# Altium schematic sheet 300mm 26V_DC Fan_V01.SchDoc
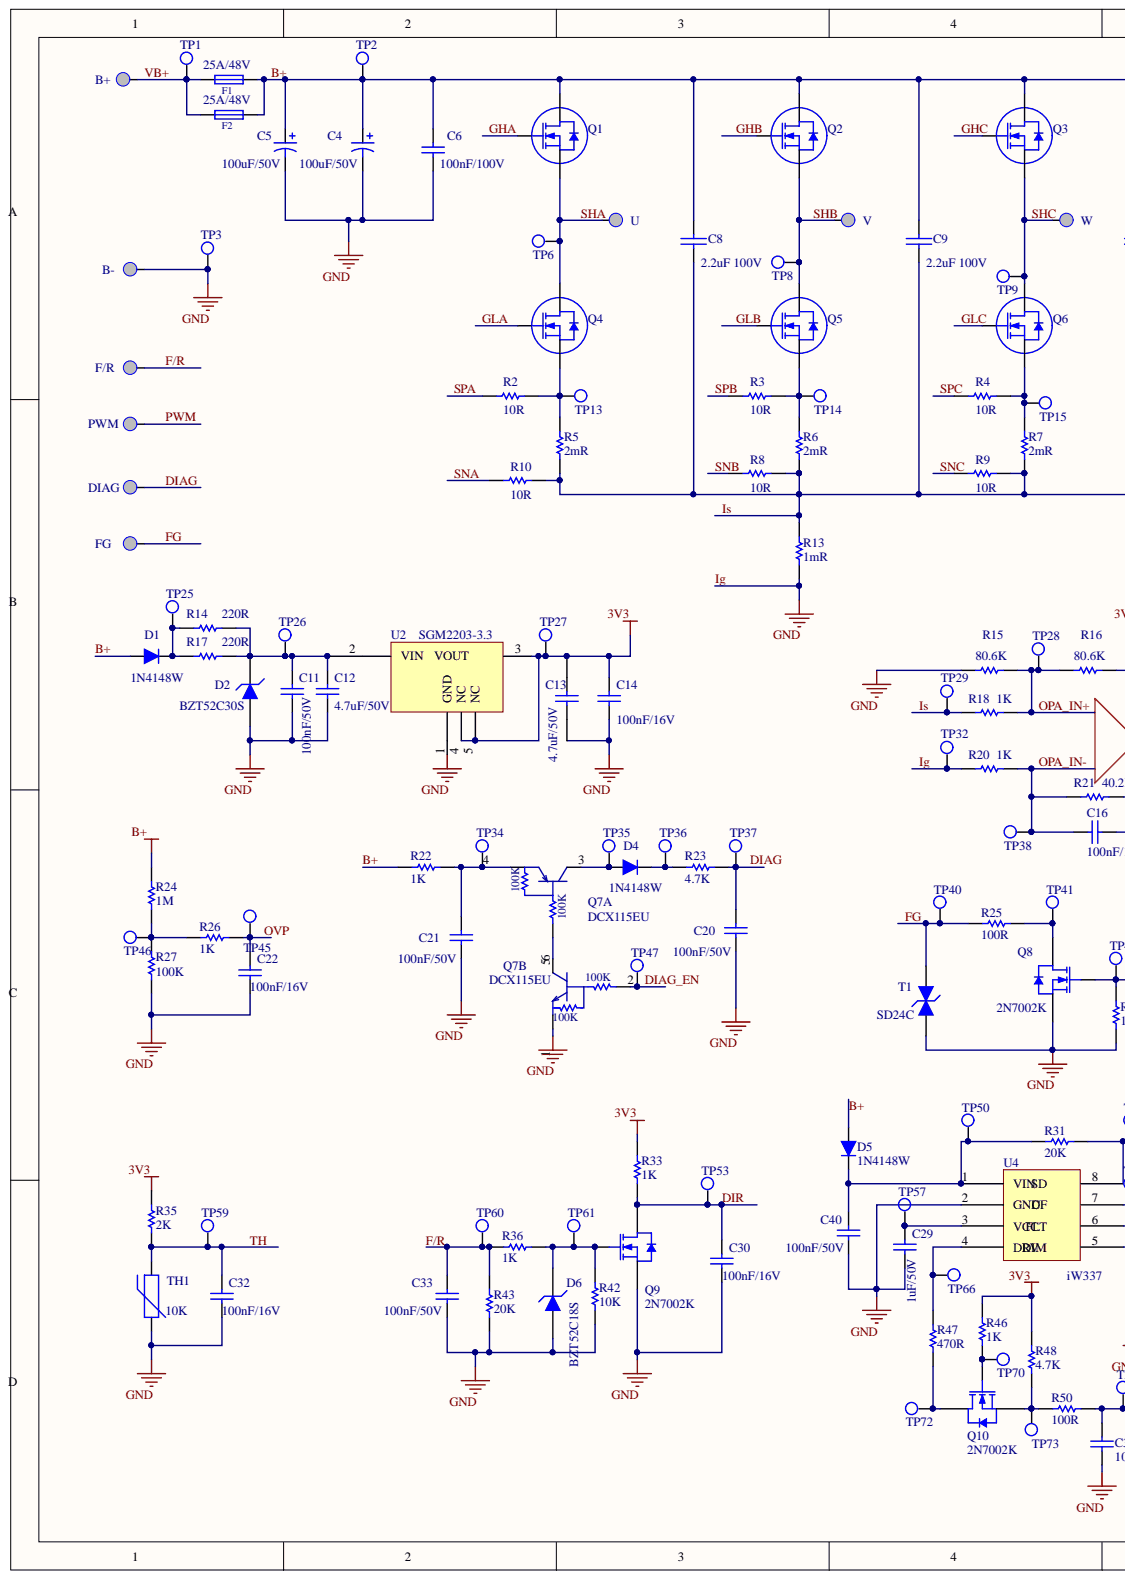
[diagram p0: 300mm 26V_DC Fan_V01.SchDoc, left]
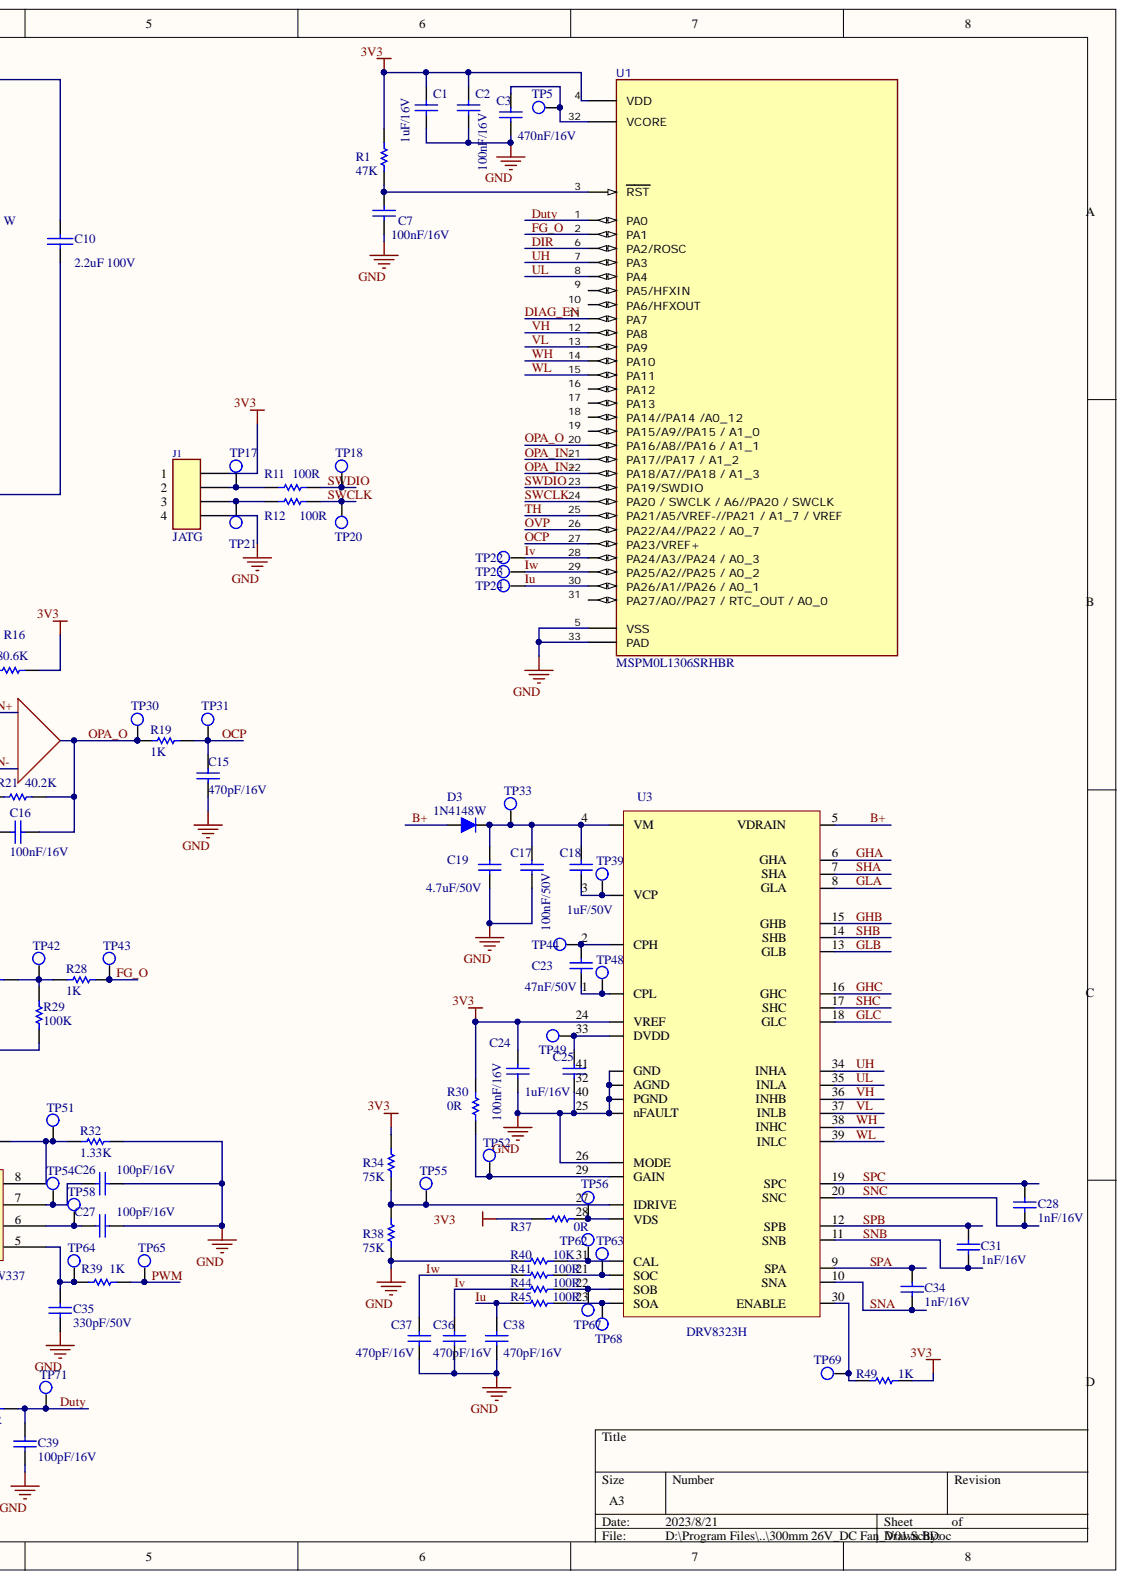
[diagram p1: 300mm 26V_DC Fan_V01.SchDoc, right]

## Parts
B+  value B+  [HOLE1.6]  (hidden comment: CONN)
B-  value B-  [HOLE1.6]  (hidden comment: CONN)
C1  value 1uF/16V  [C0603_N]  (hidden comment: Capacitor)
C2  value 100nF/16V  [C0603_N]  (hidden comment: Capacitor)
C3  value 470nF/16V  [C0603_N]  (hidden comment: Capacitor)
C4  value 100uF/50V  [ECAP SMD6.3x7.7mm]  (hidden comment: Capacitor)
C5  value 100uF/50V  [ECAP SMD6.3x7.7mm]  (hidden comment: Capacitor)
C6  value 100nF/100V  [C0603_N]  (hidden comment: Capacitor)
C7  value 100nF/16V  [C0603_N]  (hidden comment: Capacitor)
C8  value 2.2uF 100V  [CAP1206]  (hidden comment: Capacitor)
C9  value 2.2uF 100V  [CAP1206]  (hidden comment: Capacitor)
C10  value 2.2uF 100V  [CAP1206]  (hidden comment: Capacitor)
C11  value 100nF/50V  [C0603_N]  (hidden comment: Capacitor)
C12  value 4.7uF/50V  [C0805_N]  (hidden comment: Capacitor)
C13  value 4.7uF/50V  [C0805_N]  (hidden comment: Capacitor)
C14  value 100nF/16V  [C0603_N]  (hidden comment: Capacitor)
C15  value 470pF/16V  [C0603_N]  (hidden comment: Capacitor)
C16  value 100nF/16V  [C0603_N]  (hidden comment: Capacitor)
C17  value 100nF/50V  [C0603_N]  (hidden comment: Capacitor)
C18  value 1uF/50V  [C0603_N]  (hidden comment: Capacitor)
C19  value 4.7uF/50V  [C0805_N]  (hidden comment: Capacitor)
C20  value 100nF/50V  [C0603_N]  (hidden comment: Capacitor)
C21  value 100nF/50V  [C0603_N]  (hidden comment: Capacitor)
C22  value 100nF/16V  [C0603_N]  (hidden comment: Capacitor)
C23  value 47nF/50V  [C0603_N]  (hidden comment: Capacitor)
C24  value 100nF/16V  [C0603_N]  (hidden comment: Capacitor)
C25  value 1uF/16V  [C0603_N]  (hidden comment: Capacitor)
C26  value 100pF/16V  [C0603_N]  (hidden comment: Capacitor)
C27  value 100pF/16V  [C0603_N]  (hidden comment: Capacitor)
C28  value 1nF/16V  [C0603_N]  (hidden comment: Capacitor)
C29  value 1uF/50V  [C0603_N]  (hidden comment: Capacitor)
C30  value 100nF/16V  [C0603_N]  (hidden comment: Capacitor)
C31  value 1nF/16V  [C0603_N]  (hidden comment: Capacitor)
C32  value 100nF/16V  [C0603_N]  (hidden comment: Capacitor)
C33  value 100nF/50V  [C0603_N]  (hidden comment: Capacitor)
C34  value 1nF/16V  [C0603_N]  (hidden comment: Capacitor)
C35  value 330pF/50V  [C0603_N]  (hidden comment: Capacitor)
C36  value 470pF/16V  [C0603_N]  (hidden comment: Capacitor)
C37  value 470pF/16V  [C0603_N]  (hidden comment: Capacitor)
C38  value 470pF/16V  [C0603_N]  (hidden comment: Capacitor)
C39  value 100pF/16V  [C0603_N]  (hidden comment: Capacitor)
C40  value 100nF/50V  [C0603_N]  (hidden comment: Capacitor)
D1  value 1N4148W  [SOD-323]  (hidden comment: Diode)
D2  value BZT52C30S  [SOD-323]  (hidden comment: Zener)
D3  value 1N4148W  [SOD-323]  (hidden comment: Diode)
D4  value 1N4148W  [SOD-323]  (hidden comment: Diode)
D5  value 1N4148W  [SOD-323]  (hidden comment: Diode)
D6  value BZT52C18S  [SOD-323]  (hidden comment: Zener)
DIAG  value DIAG  [HOLE1.2]  (hidden comment: CONN)
F1  value 25A/48V  [R1206_L]  (hidden comment: Fuse)
F2  value 25A/48V  [R1206_L]  (hidden comment: Fuse)
F/R  value F/R  [HOLE1.2]  (hidden comment: CONN)
FG  value FG  [HOLE1.2]  (hidden comment: CONN)
J1  JATG  [HEADER * 4_PITCH2.54]
PWM  value PWM  [HOLE1.2]  (hidden comment: CONN)
Q1  MOSFET  [QFN5X6 - Infineon (PG-TDSON-8)]  (value not drawn)
Q2  MOSFET  [QFN5X6 - Infineon (PG-TDSON-8)]  (value not drawn)
Q3  MOSFET  [QFN5X6 - Infineon (PG-TDSON-8)]  (value not drawn)
Q4  MOSFET  [QFN5X6 - Infineon (PG-TDSON-8)]  (value not drawn)
Q5  MOSFET  [QFN5X6 - Infineon (PG-TDSON-8)]  (value not drawn)
Q6  MOSFET  [QFN5X6 - Infineon (PG-TDSON-8)]  (value not drawn)
Q7  value DCX115EU  [SOT363]  (hidden comment: DUAL Transistor)  (parts A,B)
Q8  value 2N7002K  [SOT-23]  (hidden comment: NMOS)
Q9  value 2N7002K  [SOT-23]  (hidden comment: NMOS)
Q10  value 2N7002K  [SOT-23]  (hidden comment: NMOS)
R1  value 47K  [R0603]  (hidden comment: Resistor)
R2  value 10R  [R0603]  (hidden comment: Resistor)
R3  value 10R  [R0603]  (hidden comment: Resistor)
R4  value 10R  [R0603]  (hidden comment: Resistor)
R5  value 2mR  [RES2512N]  (hidden comment: Resistor)
R6  value 2mR  [RES2512N]  (hidden comment: Resistor)
R7  value 2mR  [RES2512N]  (hidden comment: Resistor)
R8  value 10R  [R0603]  (hidden comment: Resistor)
R9  value 10R  [R0603]  (hidden comment: Resistor)
R10  value 10R  [R0603]  (hidden comment: Resistor)
R11  value 100R  [R0603]  (hidden comment: Resistor)
R12  value 100R  [R0603]  (hidden comment: Resistor)
R13  value 1mR  [RES2512N]  (hidden comment: Resistor)
R14  value 220R  [R0805]  (hidden comment: Resistor)
R15  value 80.6K  [R0603]  (hidden comment: Resistor)
R16  value 80.6K  [R0603]  (hidden comment: Resistor)
R17  value 220R  [R0805]  (hidden comment: Resistor)
R18  value 1K  [R0603]  (hidden comment: Resistor)
R19  value 1K  [R0603]  (hidden comment: Resistor)
R20  value 1K  [R0603]  (hidden comment: Resistor)
R21  value 40.2K  [R0603]  (hidden comment: Resistor)
R22  value 1K  [R0603]  (hidden comment: Resistor)
R23  value 4.7K  [R0603]  (hidden comment: Resistor)
R24  value 1M  [R0603]  (hidden comment: Resistor)
R25  value 100R  [R0603]  (hidden comment: Resistor)
R26  value 1K  [R0603]  (hidden comment: Resistor)
R27  value 100K  [R0603]  (hidden comment: Resistor)
R28  value 1K  [R0603]  (hidden comment: Resistor)
R29  value 100K  [R0603]  (hidden comment: Resistor)
R30  value 0R  [R0603]  (hidden comment: Resistor)
R31  value 20K  [R0603]  (hidden comment: Resistor)
R32  value 1.33K  [R0603]  (hidden comment: Resistor)
R33  value 1K  [R0603]  (hidden comment: Resistor)
R34  value 75K  [R0603]  (hidden comment: Resistor)
R35  value 2K  [R0603]  (hidden comment: Resistor)
R36  value 1K  [R0603]  (hidden comment: Resistor)
R37  value 0R  [R0603]  (hidden comment: Resistor)
R38  value 75K  [R0603]  (hidden comment: Resistor)
R39  value 1K  [R0603]  (hidden comment: Resistor)
R40  value 10K  [R0603]  (hidden comment: Resistor)
R41  value 100R  [R0603]  (hidden comment: Resistor)
R42  value 10K  [R0603]  (hidden comment: Resistor)
R43  value 20K  [R0603]  (hidden comment: Resistor)
R44  value 100R  [R0603]  (hidden comment: Resistor)
R45  value 100R  [R0603]  (hidden comment: Resistor)
R46  value 1K  [R0603]  (hidden comment: Resistor)
R47  value 470R  [R0603]  (hidden comment: Resistor)
R48  value 4.7K  [R0603]  (hidden comment: Resistor)
R49  value 1K  [R0603]  (hidden comment: Resistor)
R50  value 100R  [R0603]  (hidden comment: Resistor)
T1  value SD24C  [SOD-323]  (hidden comment: TVS)
TH1  value 10K  [R0603]  (hidden comment: NTC)
TP1  TP  [TP_1mm]
TP2  TP  [TP_1mm]
TP3  TP  [TP_1mm]
TP5  TP  [TP_1mm]
TP6  TP  [TP_1mm]
TP8  TP  [TP_1mm]
TP9  TP  [TP_1mm]
TP13  TP  [TP_1mm]
TP14  TP  [TP_1mm]
TP15  TP  [TP_1mm]
TP17  TP  [TP_1mm]
TP18  TP  [TP_1mm]
TP20  TP  [TP_1mm]
TP21  TP  [TP_1mm]
TP22  TP  [TP_1mm]
TP23  TP  [TP_1mm]
TP24  TP  [TP_1mm]
TP25  TP  [TP_1mm]
TP26  TP  [TP_1mm]
TP27  TP  [TP_1mm]
TP28  TP  [TP_1mm]
TP29  TP  [TP_1mm]
TP30  TP  [TP_1mm]
TP31  TP  [TP_1mm]
TP32  TP  [TP_1mm]
TP33  TP  [TP_1mm]
TP34  TP  [TP_1mm]
TP35  TP  [TP_1mm]
TP36  TP  [TP_1mm]
TP37  TP  [TP_1mm]
TP38  TP  [TP_1mm]
TP39  TP  [TP_1mm]
TP40  TP  [TP_1mm]
TP41  TP  [TP_1mm]
TP42  TP  [TP_1mm]
TP43  TP  [TP_1mm]
TP44  TP  [TP_1mm]
TP45  TP  [TP_1mm]
TP46  TP  [TP_1mm]
TP47  TP  [TP_1mm]
TP48  TP  [TP_1mm]
TP49  TP  [TP_1mm]
TP50  TP  [TP_1mm]
TP51  TP  [TP_1mm]
TP52  TP  [TP_1mm]
TP53  TP  [TP_1mm]
TP54  TP  [TP_1mm]
TP55  TP  [TP_1mm]
TP56  TP  [TP_1mm]
TP57  TP  [TP_1mm]
TP58  TP  [TP_1mm]
TP59  TP  [TP_1mm]
TP60  TP  [TP_1mm]
TP61  TP  [TP_1mm]
TP62  TP  [TP_1mm]
TP63  TP  [TP_1mm]
TP64  TP  [TP_1mm]
TP65  TP  [TP_1mm]
TP66  TP  [TP_1mm]
TP67  TP  [TP_1mm]
TP68  TP  [TP_1mm]
TP69  TP  [TP_1mm]
TP70  TP  [TP_1mm]
TP71  TP  [TP_1mm]
TP72  TP  [TP_1mm]
TP73  TP  [TP_1mm]
U  value U  [HOLE1.5]  (hidden comment: CONN)
U1  value MSPM0L1306SRHBR  [VQFN-32]  (hidden comment: IC)
U2  value SGM2203-3.3  [SOT-23-5]  (hidden comment: LDO)
U3  value DRV8323H  [TI DRV8323H WQFN40]  (hidden comment: IC)
U4  value iW337  [SOIC-8_150MIL]  (hidden comment: IC)
V  value V  [HOLE1.5]  (hidden comment: CONN)
W  value W  [HOLE1.5]  (hidden comment: CONN)

## Nets
3V3: C1.2 C2.2 C13.2 C14.2 C24.2 J1.1 R1.2 R16.1 R30.2 R33.1 R34.2 R35.1 R37.2 R46.1 R48.1 R49.1 TP27.1 U1.4(VDD) U2.3 U2.4(NC) U2.5(NC) U3.24(VREF)
B+: C4.1 C5.1 C6.2 C8.1 C9.1 C10.1 D1.1 D3.1 D5.1 F1.2 F2.2 Q1.4 Q2.4 Q3.4 R22.2 R24.1 TP2.1 U3.5
DIAG: C20.2 DIAG.1 R23.1 TP37.1
DIAG_EN: Q7.2 TP47.1 U1.11(PA7)
DIR: C30.2 Q9.3 R33.2 TP53.1 U1.6(PA2/ROSC)
DUTY: C39.1 R50.1 TP71.1 U1.1(PA0)
F/R: C33.2 F/R.1 R36.2 R43.1 TP60.1
FG: FG.1 R25.2 T1.2 TP40.1
FG_O: R28.2 TP43.1 U1.2(PA1)
GHA: Q1.1 U3.6
GHB: Q2.1 U3.15
GHC: Q3.1 U3.16
GLA: Q4.1 U3.8
GLB: Q5.1 U3.13
GLC: Q6.1 U3.18
IG: B-.1 C1.1 C2.1 C3.1 C4.2 C5.2 C6.1 C7.1 C11.1 C12.1 C13.1 C14.1 C15.1 C17.1 C19.1 C20.1 C21.1 C22.1 C24.1 C25.1 C26.1 C27.1 C29.1 C30.1 C32.1 C33.1 C35.2 C36.1 C37.1 C38.1 C39.2 C40.1 D2.1 D6.1 J1.4 Q7.1 Q8.2 Q9.2 R13.1 R15.2 R20.2 R27.2 R29.2 R32.2 R38.1 R40.2 R42.2 R43.2 T1.1 TH1.1 TP3.1 TP32.1 U1.5(VSS) U1.33(PAD) U2.1(GND) U3.25(nFAULT) U3.26(MODE) U3.32(AGND) U3.40(PGND) U3.41(GND) U4.2(GND)
IS: C8.2 C9.2 C10.2 R5.1 R6.1 R7.1 R8.1 R9.1 R10.1 R13.2 R18.2 TP29.1
IU: C38.2 R45.2 TP24.1 U1.30(PA26/A1//PA26_/_A0_1)
IV: C36.2 R44.2 TP22.1 U1.28(PA24/A3//PA24_/_A0_3)
IW: C37.2 R41.2 TP23.1 U1.29(PA25/A2//PA25_/_A0_2)
OCP: C15.2 R19.2 TP31.1 U1.27(PA23/VREF+)
OPA_IN+: R15.1 R16.2 R18.1 TP28.1 U1.22(PA18/A7//PA18_/_A1_3)
OPA_IN-: C16.2 R20.1 R21.2 TP38.1 U1.21(PA17//PA17_/_A1_2)
OPA_O: C16.1 R19.1 R21.1 TP30.1 U1.20(PA16/A8//PA16_/_A1_1)
OVP: C22.2 R26.1 TP45.1 U1.26(PA22/A4//PA22_/_A0_7)
PWM: PWM.1 R39.1 TP65.1
SHA: Q1.3 Q4.4 TP6.1 U.1 U3.7
SHB: Q2.3 Q5.4 TP8.1 U3.14 V.1
SHC: Q3.3 Q6.4 TP9.1 U3.17 W.1
SNA: C34.1 R10.2 U3.10
SNB: C31.1 R8.2 U3.11
SNC: C28.1 R9.2 U3.20
SPA: C34.2 R2.2 U3.9
SPB: C31.2 R3.2 U3.12
SPC: C28.2 R4.2 U3.19
SWCLK: R12.1 TP20.1 U1.24(PA20_/_SWCLK_/_A6//PA20_/_SWCLK)
SWDIO: R11.1 TP18.1 U1.23(PA19/SWDIO)
TH: C32.2 R35.2 TH1.2 TP59.1 U1.25(PA21/A5/VREF-//PA21_/_A1_7_/_VREF)
UH: U1.7(PA3) U3.34
UL: U1.8(PA4) U3.35
VB+: B+.1 F1.1 F2.1 TP1.1
VH: U1.12(PA8) U3.36
VL: U1.13(PA9) U3.37
WH: U1.14(PA10) U3.38
WL: U1.15(PA11) U3.39
NetC3_2: C3.2 TP5.1 U1.32(VCORE)
NetC7_2: C7.2 R1.1 U1.3(RST)
NetC11_2: C11.2 C12.2 D2.2 R14.2 R17.2 TP26.1 U2.2(VIN)
NetC17_2: C17.2 C18.2 C19.2 D3.2 TP33.1 U3.4(VM)
NetC18_1: C18.1 TP39.1 U3.3(VCP)
NetC21_2: C21.2 Q7.4 R22.1 TP34.1
NetC23_1: C23.1 TP48.1 U3.1(CPL)
NetC23_2: C23.2 TP44.1 U3.2(CPH)
NetC25_2: C25.2 TP49.1 U3.33(DVDD)
NetC26_2: C26.2 TP54.1 U4.7
NetC27_2: C27.2 TP58.1 U4.6
NetC29_2: C29.2 TP57.1 U4.3(VCC)
NetC35_1: C35.1 R39.2 TP64.1 U4.5
NetC40_2: C40.2 D5.2 R31.1 TP50.1 U4.1(VIN)
NetD1_2: D1.2 R14.1 R17.1 TP25.1
NetD4_1: D4.1 Q7.3 TP35.1
NetD4_2: D4.2 R23.2 TP36.1
NetD6_2: D6.2 Q9.1 R36.1 R42.1 TP61.1
NetJ1_2: J1.2 R11.2 TP17.1
NetJ1_3: J1.3 R12.2 TP21.1
NetQ4_3: Q4.3 R2.1 R5.2 TP13.1
NetQ5_3: Q5.3 R3.1 R6.2 TP14.1
NetQ6_3: Q6.3 R4.1 R7.2 TP15.1
NetQ7_5: Q7.5 Q7.6
NetQ8_1: Q8.1 R28.1 R29.1 TP42.1
NetQ8_3: Q8.3 R25.1 TP41.1
NetQ10_1: Q10.1 R46.2 TP70.1
NetQ10_2: Q10.2 R48.2 R50.2 TP73.1
NetQ10_3: Q10.3 R47.2 TP72.1
NetR24_2: R24.2 R26.2 R27.1 TP46.1
NetR30_1: R30.1 TP52.1 U3.29(GAIN)
NetR31_2: R31.2 R32.1 TP51.1 U4.8
NetR34_1: R34.1 R38.2 TP55.1 U3.27(IDRIVE)
NetR37_1: R37.1 TP56.1 U3.28(VDS)
NetR40_1: R40.1 TP62.1 U3.31(CAL)
NetR41_1: R41.1 TP63.1 U3.21(SOC)
NetR44_1: R44.1 TP67.1 U3.22(SOB)
NetR45_1: R45.1 TP68.1 U3.23(SOA)
NetR47_1: R47.1 TP66.1 U4.4(DRV)
NetR49_2: R49.2 TP69.1 U3.30

Not connected: U1 9(PA5/HFXIN) 10(PA6/HFXOUT) 16(PA12) 17(PA13) 18(PA14//PA14_/A0_12) 19(PA15/A9//PA15_/_A1_0) 31(PA27/A0//PA27_/_RTC_OUT_/_A0_0)

## Library data of the parts
B+ = CN: B+; Component Kind: Standard; Library Name: Andy SCH.SchLib
B- = CN: B-; Component Kind: Standard; Library Name: Andy SCH.SchLib
C1 C25 = CAP 1uF 16V X7R 0603: CAP 1uF 10V X7R 0603 SMD; Tolerance: ±15%; Type: SMT; Component Kind: Standard; Operating temperature: -55C - 125C; Package: 0603; Rated Voltage: 10 V; Library Name: 2016 Library_Suzhou.SchLib
C2 C7 C22 C24 C30 C32 = CAP SMD0603: 100nF 16V X7R SMD0603; Component Kind: Standard; Package: 0603; Library Name: tester4.SchLib
C3 = CAP SMD0603: 470nF 16V X7R SMD0603; Component Kind: Standard; Package: 0603; Library Name: tester4.SchLib
C4 C5 = Cap Pol1: VZT221M1ETR-0607/Lelon; Manufacturer: Lelon; Part number: C371974; Component Kind: Standard
C6 = CAP SMD0603: 100nF 100V X7R SMD0603; Component Kind: Standard; Package: 0402; Library Name: tester4.SchLib
C8-C10 = CAP: 2.2uF 100V X7R 1206; Component Kind: Standard
  C8 C9: Value: 2.2uF 100V
C11 C17 C20 C21 C33 C40 = CAP SMD0603: 100nF 50V X7R SMD0603; Component Kind: Standard; Package: 0603; Library Name: tester4.SchLib
C12 C13 C19 = CAP SMD0603: 4.7uF 50V X7R SMD0805; Component Kind: Standard; Package: 0805; Library Name: tester4.SchLib
C14 = CAP SMD0603: 100nF 16V SMD0603; Component Kind: Standard; Package: 0603; Library Name: tester4.SchLib
C15 = CAP 470pF 50V X7R 0402: 470pF 16V X7R 0603SMD; Rated Voltage: 10 V; Tolerance: ±15%; Type: SMT; Component Kind: Standard; Operating temperature: -55C - 125C; Package: 0603; Library Name: 2016 Library_Suzhou.SchLib
C16 = CAP SMD0603: 1nF 16V X7R SMD0603; Component Kind: Standard; Package: 0603; Library Name: tester4.SchLib
C18 = CAP SMD0603: 1uF 50V X7R SMD0603; Value: 1uF/50V; Component Kind: Standard; Package: 0603; Library Name: tester4.SchLib
C23 = CAP 47nF 50V X7R 0402: 47nF 50V X7R SMD0603; Tolerance: ±15%; Type: SMT; Component Kind: Standard; Operating temperature: -55C - 125C; Package: 0603; Rated Voltage: 50 V; Library Name: 2016 Library_Suzhou.SchLib
C26 C27 C39 = CAP SMD0603: 100pF 16V X7R SMD0603; Component Kind: Standard; Package: 0603; Library Name: tester4.SchLib
C28 C31 C34 = CAP 1nF 25V X7R 0402: 1nF 16V X7R 0603 SMD; Tolerance: ±15%; Type: SMT; Component Kind: Standard; Operating temperature: -55C - 125C; Package: 0603; Rated Voltage: 16 V; Library Name: 2016 Library_Suzhou.SchLib
C29 = CAP 1uF 35V X7R 0603: CAP 1uF 16V X7R 0603 SMD; Rated Voltage: 35 V; Tolerance: ±15%; Type: SMT; Component Kind: Standard; Operating temperature: -55C - 125C; Package: 0603; Library Name: 2016 Library_Suzhou.SchLib
C35 = CAP SMD0603: 330pF 50V X7R SMD0603; Component Kind: Standard; Package: 0603; Library Name: tester4.SchLib
C36-C38 = CAP 470pF 50V X7R 0402: 470pF 16V X7R 0603 SMD; Tolerance: ±15%; Type: SMT; Component Kind: Standard; Operating temperature: -55C - 125C; Package: 0603; Rated Voltage: 16V; Library Name: 2016 Library_Suzhou.SchLib
D1 D3-D5 = Diode: 1N4148W; Component Kind: Standard; Library Name: tester4.SchLib
  D4: Value: 1N4148W
D2 = Zener: BZT52C30S; manufacture: JSCJ; Component Kind: Standard; Library Name: tester4.SchLib
D6 = Zener: BZT52C18S; manufacture: JSCJ; Component Kind: Standard; Library Name: tester4.SchLib
DIAG F/R FG PWM = CN: F/R; Component Kind: Standard; Library Name: Andy SCH.SchLib
F1 F2 = FUSE1-1: 25A/48V; Component Kind: Standard
J1 = CN4: CN; Component Kind: Standard
Q1-Q6 = TR-NMOS IRFS3206PbF 60V 210A 2.4mOhm D2PaK: CSD17576Q5B,QFN5x6; Component Kind: Standard; Package: QFN 5X6; Supplier 1: TI; Supplier Part Number 1: AUIRFS3206TRR-ND; Library Name: tester4.SchLib
Q7 = TR PNP+NPN 50V 20mA (100K resistors): TR PNP+NPN DCX115EU SOT363; Current (max): 30mA; Type: PNP+NPN with 22K resistors; Vce(sat): 0.3V; Component Kind: Standard; Datasheet: http://www.diodes.com/_files/datasheets/ds30350.pdf; moisture Sensitivity: Level 1 per J-STD-020D; Operating temperature: -55 to +150; Package: SC-74R; Library Name: tester4.SchLib
Q8-Q10 = 2N7002: 2N7002K, 60V 300mA SOT23; Component Kind: Standard; Package: SOT23; Supplier 1: ON/DIODES/NXP; Supplier Part Number 1: 1510761; Library Name: tester4.SchLib
R1 = RES 10K 5% 1/16W 0402: 47K 1/10W 1% 0603 SMD; Power: 1/16W; Tolerance: 5 %; Component Kind: Standard; Package: 0603; Type: SMT; Library Name: 2016 Library_Suzhou.SchLib
R2-R4 R8-R10 = RES 10K 5% 1/16W 0402: 10R 1/10W 1% 0603 SMD; Tolerance: 5 %; Component Kind: Standard; Package: 0603; Power: 1/10W; Type: SMT; Library Name: 2016 Library_Suzhou.SchLib
R5-R7 = RES 100R 5% 1/4W 1206: 2mR 3W 1% 2512 SMD; Component Kind: Standard; Package: 1206; Power: 3W; Tolerance: 1 %; Type: SMT; Library Name: 2016 Library_Suzhou.SchLib
R11 R12 R25 R50 = RES SMD0603: 100R 1/10W 1% SMD0603; Component Kind: Standard; Tolerance: 1 %; Type: SMT; Library Name: tester4.SchLib
R13 = RES 100R 5% 1/4W 1206: 1mR 3W 1% 2512 SMD; Component Kind: Standard; Package: 1206; Power: 3W; Tolerance: 1 %; Type: SMT; Library Name: 2016 Library_Suzhou.SchLib
R14 R17 = RES SMD0805: 0R 1/8W 5% 0805 SMD; Component Kind: Standard; Package: 0805; Tolerance: 5 %; Library Name: tester4.SchLib
R15 R16 = RES SMD0603: 80.6K 1/10W 1% SMD0603; Component Kind: Standard; Tolerance: 1 %; Type: SMT; Library Name: tester4.SchLib
R18 R20 R22 R26 R36 R39 R46 = RES SMD0603: 1K 1/10W 1% SMD0603; Component Kind: Standard; Tolerance: 1 %; Type: SMT; Library Name: tester4.SchLib
R19 R28 R33 = RES SMD0603: 1K 1%1/10W SMD0603; Tolerance: 1 %; Component Kind: Standard; Type: SMT; Library Name: tester4.SchLib
R21 = RES SMD0603: 40.2K 1/10W 1% SMD0603; Component Kind: Standard; Tolerance: 1 %; Type: SMT; Library Name: tester4.SchLib
R23 R48 = RES SMD0603: 4.7K 1/10W 1% SMD0603; Component Kind: Standard; Tolerance: 1 %; Type: SMT; Library Name: tester4.SchLib
R24 = RES SMD0603: 1M 1/10W 1% SMD0603; Component Kind: Standard; Tolerance: 1 %; Type: SMT; Library Name: tester4.SchLib
R27 R31 R43 = RES SMD0603: 100K 1/10W 1% SMD0603; Component Kind: Standard; Tolerance: 1 %; Type: SMT; Library Name: tester4.SchLib
R29 = RES SMD0603: 100K 1%1/10W SMD0603; Tolerance: 1 %; Component Kind: Standard; Type: SMT; Library Name: tester4.SchLib
R30 R37 = RES 10K 5% 1/16W 0402: 0R 1/10W 5% 0603 SMD; Component Kind: Standard; Package: 0603; Power: 1/10W; Tolerance: 5 %; Type: SMT; Library Name: 2016 Library_Suzhou.SchLib
R32 = RES SMD0603: 1.33K 1/10W 1% SMD0603; Component Kind: Standard; Tolerance: 1 %; Type: SMT; Library Name: tester4.SchLib
R34 R38 = RES 10K 5% 1/16W 0402: 75K 1/10W 1% 0603SMD; Power: 1/16W; Component Kind: Standard; Package: 0603; Tolerance: 1 %; Type: SMT; Library Name: 2016 Library_Suzhou.SchLib
R35 = RES SMD0603: 2K 1/10W 1% SMD0603; Component Kind: Standard; Tolerance: 1 %; Type: SMT; Library Name: tester4.SchLib
R40 = RES 10K 5% 1/16W 0402: 10K 1/10W 1% 0603 SMD; Tolerance: 5 %; Component Kind: Standard; Package: 0603; Power: 1/10W; Type: SMT; Library Name: 2016 Library_Suzhou.SchLib
R41 R44 R45 = RES 10K 5% 1/16W 0402: 100R 1/10W 1% 0603 SMD; Tolerance: 5 %; Component Kind: Standard; Package: 0603; Power: 1/10W; Type: SMT; Library Name: 2016 Library_Suzhou.SchLib
R42 = RES SMD0603: 10K 1/10W 1% SMD0603; Component Kind: Standard; Tolerance: 1 %; Type: SMT; Library Name: tester4.SchLib
R47 = RES SMD0603: 470R 1/10W 1% SMD0603; Component Kind: Standard; Tolerance: 1 %; Type: SMT; Library Name: tester4.SchLib
R49 = RES 10K 5% 1/16W 0402: 1K 1/10W 1% 0603 SMD; Tolerance: 5 %; Component Kind: Standard; Package: 0603; Power: 1/10W; Type: SMT; Library Name: 2016 Library_Suzhou.SchLib
T1 = TVS: SD24C; Manufacture: ChangJing; Component Kind: Standard; Library Name: tester4.SchLib
TH1 = MDG-RES-000005: THERMISTOR 10K OHM PTC 1% 0402; Database Table Name: Resistors; Component Kind: Standard; Library Name: MDG-Components.DbLib; Size: 0402; Supplier 1: Digi-Key; Supplier Part Number 1: 445-174523-1-ND
TP1-TP3 TP5 TP6 TP8 TP9 TP13-TP15 TP17 TP18 TP20-TP73 = TP_1mm: Component Kind: Standard; Library Name: tester4.SchLib
U = CN: U; Component Kind: Standard; Library Name: Andy SCH.SchLib
U1 = MSPM0L1306SRHBR: Manufacturer: TI; Component Kind: Standard; Description: MSPM0L1306SRHBR; Library Name: tester4.SchLib
U2 = SGM2203-5: Component Kind: Standard; Description: SGM2203-5; Library Name: tester4.SchLib
U3 = TI DRV8323H: DRV8323H; manufacture: TI; Component Kind: Standard; Library Name: tester4.SchLib
U4 = iW337: manufacture: Renesas; Component Kind: Standard; Description: iW337; Library Name: tester4.SchLib
V = CN: V; Component Kind: Standard; Library Name: Andy SCH.SchLib
W = CN: W; Component Kind: Standard; Library Name: Andy SCH.SchLib
